# Altium schematic sheet OpenRing_MPU.SchDoc
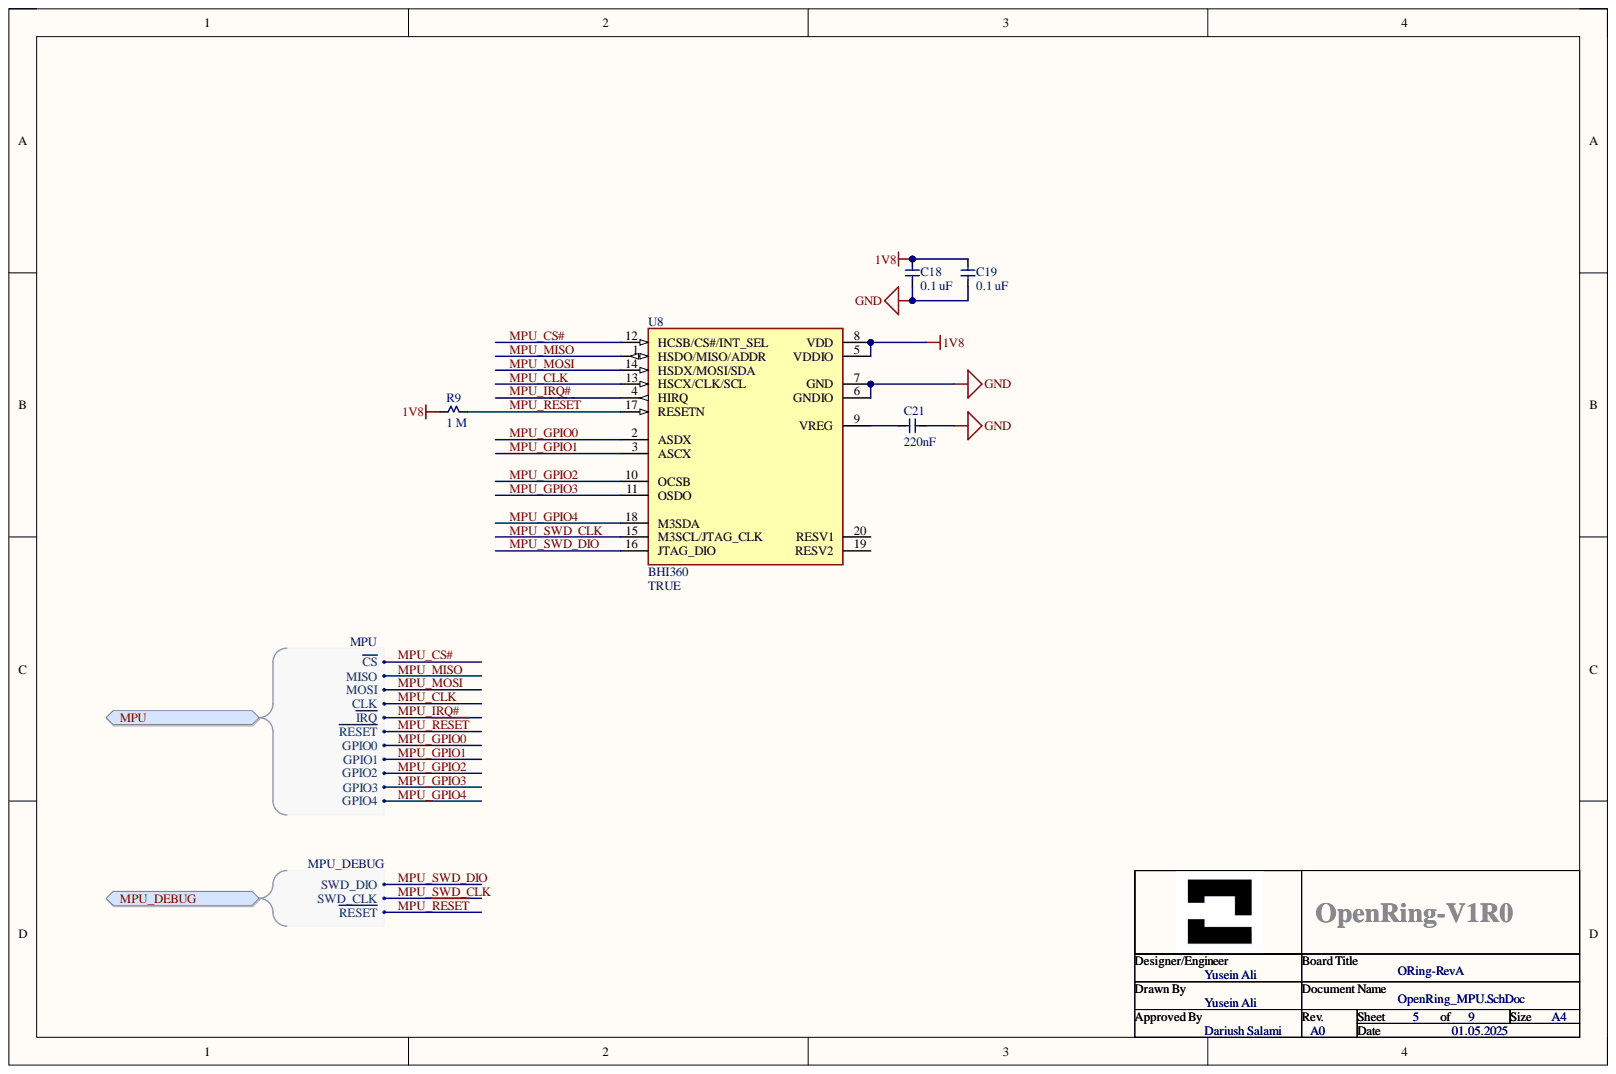
[diagram p0: OpenRing_MPU.SchDoc]

## Parts
C18  0.1 uF  [CAPC1005X05N]
C19  0.1 uF  [CAPC1005X05N]
C21  220nF  [CAPC1005X05N]
R9  1 M  [RESC1005X04N]
U8  BHI360  Checked: TRUE  [BHI360]

## Ports
MPU, MPU.CLK, MPU.GPIO0, MPU.GPIO1, MPU.GPIO2, MPU.GPIO3, MPU.GPIO4, MPU.MISO, MPU.MOSI, MPU.~{CS}, MPU.~{IRQ}, MPU.~{RESET}, MPU_DEBUG, MPU_DEBUG.SWD_CLK, MPU_DEBUG.SWD_DIO, MPU_DEBUG.~{RESET}

## Nets
1V8: C18.2 C19.2 R9.1 U8.5(VDDIO) U8.8(VDD)
GND: C18.1 C19.1 C21.2 U8.6(GNDIO) U8.7(GND)
MPU_CLK: U8.13(HSCX/CLK/SCL) ; port MPU
MPU_CS#: U8.12(HCSB/CS#/INT_SEL) ; port MPU
MPU_GPIO0: U8.2(ASDX) ; port MPU
MPU_GPIO1: U8.3(ASCX) ; port MPU
MPU_GPIO2: U8.10(OCSB) ; port MPU
MPU_GPIO3: U8.11(OSDO) ; port MPU
MPU_GPIO4: U8.18(M3SDA) ; port MPU
MPU_IRQ#: U8.4(HIRQ) ; port MPU
MPU_MISO: U8.1(HSDO/MISO/ADDR) ; port MPU
MPU_MOSI: U8.14(HSDX/MOSI/SDA) ; port MPU
MPU_~{RESET}: R9.2 U8.17(RESETN) ; port MPU, port MPU_DEBUG
MPU_SWD_CLK: U8.15(M3SCL/JTAG_CLK) ; port MPU_DEBUG
MPU_SWD_DIO: U8.16(JTAG_DIO) ; port MPU_DEBUG
NetC21_1: C21.1 U8.9(VREG)

Not connected: U8 19(RESV2) 20(RESV1)

## Library data of the parts
C18 C19 = GRM155R61C104KA88D: CAP CER 0.1UF 16V X5R 0402; Brand: Murata Electronics; Capacitance - nF: 100 nF; Capacitance - pF: 100000 pF; Tolerance: 10 %; Type: Chip Multilayer Ceramic Capacitor for General Purpose; Voltage Rating DC: 16 VDC; Capacitance: 0.1 uF; Case Code - in: 0402; Case Code - mm: 1005; Category: Multilayer Ceramic Capacitors MLCC - SMD/SMT; Component Kind: Standard; ComponentLink1Description: http://www.mouser.com/datasheet/2/281/murata_03052018_GRM_Series_1-1310166.pdf; ComponentLink1URL: http://www.mouser.com/datasheet/2/281/murata_03052018_GRM_Series_1-1310166.pdf; Dielectric: X5R; Height: 0.5 mm; Length: 1 mm; Manufacturer: Murata Electronics; Manufacturer Part Number: GRM155R61C104KA88D; Maximum Operating Temperature: + 85 C; Minimum Operating Temperature: - 55 C; Minimum Order Quantity: 1; Package / Case: 0402 (1005 metric); Packaging: Cut Tape; Pricing: 1=0.082, 10=0.026, 100=0.011, 500=0.008, 1000=0.007, 2500=0.006, 5000=0.005, 10000=0.004 (EUR); Product: General Type MLCCs; Reeling: True; RoHS: RoHS Compliant; RoHS - Mouser: Y; Series: GRM; Standard Pack Qty: 10000; Stock: 0; Supplier 1: Mouser; Supplier 2: Digikey; Supplier 3: Digikey; Supplier Part Number 1: 81-GRM155R61C104KA8D; Supplier Part Number 2: 490-5415-1-ND; Supplier Part Number 3: 490-5415-6-ND; Termination: Standard; Termination Style: SMD/SMT; Value: 0.1 uF; Width: 0.5 mm; Library Name: OpenRingComponents.IntLib
C21 = GRM155R61C224KA12D: CAP CER 0.22UF 16V X5R 0402; Manufacturer: Murata; Category: Ceramic Capacitors; Component Kind: Standard; ComponentLink1Description: http://www.mouser.com/ds/2/281/c02e-2905.pdf?src-supplier=Mouser; ComponentLink1URL: http://www.mouser.com/ds/2/281/c02e-2905.pdf?src-supplier=Mouser; ComponentLink2Description: https://www.murata.com/~/media/webrenewal/support/library/catalog/products/capacitor/mlcc/c02e.ashx?la=en-us&src-supplier=Digikey; ComponentLink2URL: https://www.murata.com/~/media/webrenewal/support/library/catalog/products/capacitor/mlcc/c02e.ashx?la=en-us&src-supplier=Digikey; ComponentLink3Description: http://search.murata.co.jp/Ceramy/image/img/A01X/G101/ENG/GRM155R61C224KA12-01.pdf?src-supplier=Digikey; ComponentLink3URL: http://search.murata.co.jp/Ceramy/image/img/A01X/G101/ENG/GRM155R61C224KA12-01.pdf?src-supplier=Digikey; ComponentLink4Description: http://search.murata.co.jp/Ceramy/image/img/A01X/partnumbering_e_01.pdf?src-supplier=Digikey; ComponentLink4URL: http://search.murata.co.jp/Ceramy/image/img/A01X/partnumbering_e_01.pdf?src-supplier=Digikey; ComponentLink5Description: https://datasheet.octopart.com/GRM155R61C224KA12D-Murata-datasheet-22122223.pdf?src-supplier=Future+Electronics; ComponentLink5URL: https://datasheet.octopart.com/GRM155R61C224KA12D-Murata-datasheet-22122223.pdf?src-supplier=Future+Electronics; Lead Free: 5697LeadFree; Manufacturer Part Number: GRM155R61C224KA12D; Pricing: 1=0.1, 10=0.043, 50=0.043, 100=0.026, 1000=0.017, 10000=0.009 (USD); RoHS: Yes; Stock: 8589; Supplier: Mouser; Supplier 1: Mouser; Supplier 2: Digikey; Supplier Part Number: 81-GRM155R61C224KA2D; Supplier Part Number 1: 81-GRM155R61C224KA2D; Supplier Part Number 2: 490-11416-1-ND; Value: 220nF; Library Name: OpenRingComponents.IntLib
R9 = ERJ-2RKF1004X: RES SMD 1M OHM 1% 1/10W 0402; Manufacturer: Panasonic Electronic Components; Power (Watts): 0.1W, 1/10W; Temperature Coefficient: ±100ppm/°C; Category: Resistors; Component Kind: Standard; ComponentLink1Description: https://industrial.panasonic.com/cdbs/www-data/pdf/RDA0000/AOA0000C283.pdf; ComponentLink1URL: https://industrial.panasonic.com/cdbs/www-data/pdf/RDA0000/AOA0000C283.pdf; Composition: Thick Film; Failure Rate: -; Features: Automotive AEC-Q200; Height - Seated (Max): 0.016" (0.40mm); Manufacturer Part Number: ERJ-2RKF1004X; Number of Terminations: 2; Operating Temperature: -55°C ~ 155°C; Package / Case: 0402 (1005 Metric); Packaging: Digi-Reel®; Part Status: Active; Pricing 1: 1=0.1, 10=0.025, 25=0.018, 50=0.0136, 100=0.01, 250=0.00764, 500=0.00612, 1000=0.00451, 5000=0.00323 (USD); Resistance (Ohms): 1M; RoHS: RoHS Compliant; Signal Integrity: Model Name; Size / Dimension: 0.039" L x 0.020" W (1.00mm x 0.50mm); Stock 1: 1590446; Supplier 1: Digi-Key; Supplier Device Package: 0402; Supplier Part Number 1: P1.00MLDKR-ND; Tolerance: ±1%; Value: 1 M; Library Name: OpenRingComponents.IntLib
U8 = BHI360: Programmable smart sensor combining accelerometer, gyroscope and fusion software; Manufacturer: Bosch Tools; Category: Uncategorized; Component Kind: Standard; Library Name: ICs.SchLib; Manufacturer Part Number: BHI360; Pricing: 1=6.54, 10=5.232, 25=4.8396, 100=4.1854, 500=3.92384, 1000=3.66226 (USD); RoHS: No; Stock: 17060; Supplier: Digikey; Supplier 1: Digikey; Supplier 2: Mouser; Supplier Part Number: 828-BHI360DKR-ND; Supplier Part Number 1: 828-BHI360DKR-ND; Supplier Part Number 2: 262-BHI360
